# Altium schematic sheet peripheral.SchDoc(peripheral)
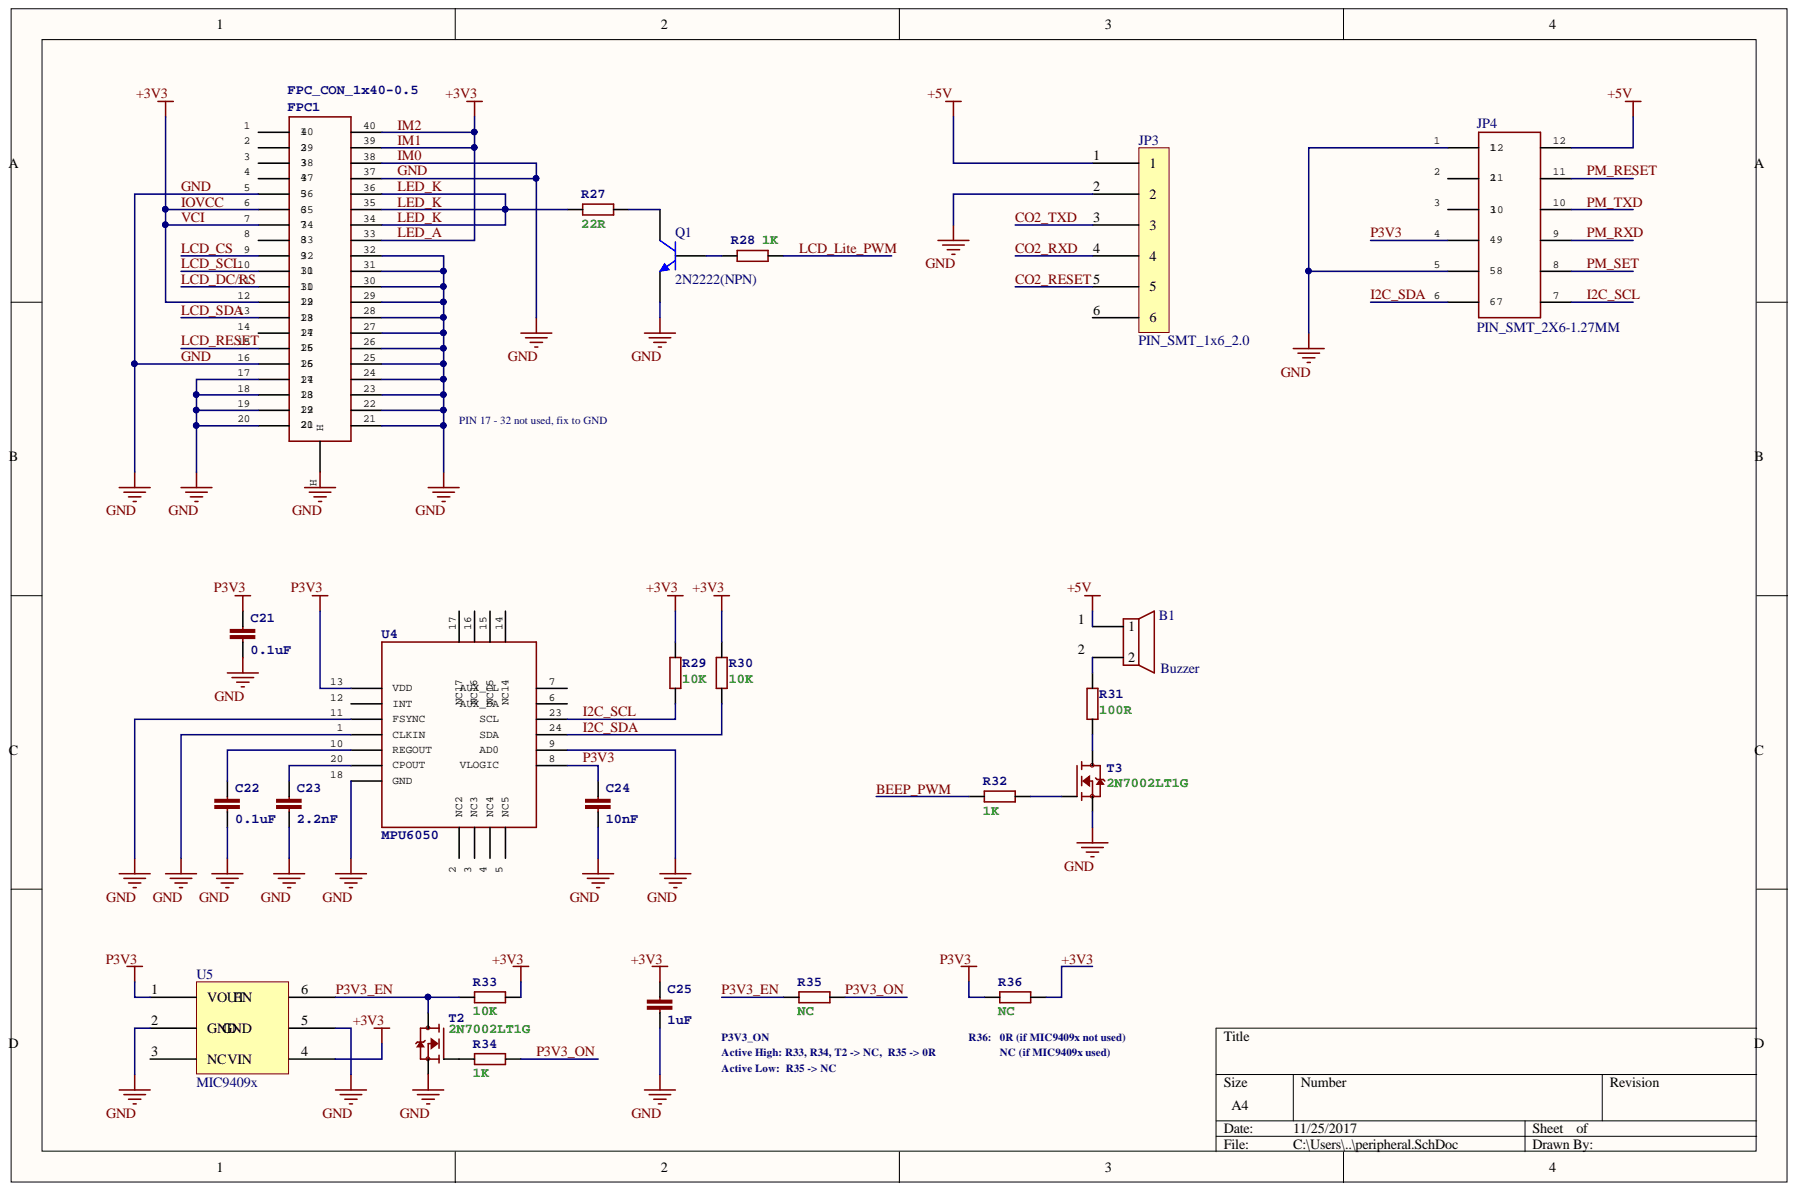
[diagram p0: peripheral.SchDoc]

## Parts
B1  Buzzer  [BUZZER_5020_1]
C21  0.1uF  [C0603]
C22  0.1uF  [C0603]
C23  2.2nF  [C0603]
C24  10nF  [C0603]
C25  1uF  [C0603]
FPC1  FPC_CON_1x40-0.5  [FPC_CON_1X40-0.5MM]
JP3  PIN_SMT_1x6_2.0  [PIN_1x6_SMT_2.0]
JP4  PIN_SMT_2X6-1.27MM  [PIN_2x6_1.27]
Q1  2N2222(NPN)  [S8050(NPN)]
R27  22R  [R0603]
R28  1K  [R0603]
R29  10K  [R0603]
R30  10K  [R0603]
R31  100R  [R0603]
R32  1K  [R0603]
R33  10K  [R0603]
R34  1K  [R0603]
R35  NC  [R0603]
R36  NC  [R0603]
T2  2N7002LT1G  [SOT-23]
T3  2N7002LT1G  [SOT-23]
U4  MPU6050  [QFN-24-4MM2]
U5  MIC9409x  [SC70-6]

## Nets
+5V: B1.1 JP3.1 JP4.12
+3V3: C25.1 FPC1.6 FPC1.7 FPC1.12 FPC1.33 FPC1.39 FPC1.40 R29.2 R30.2 R33.2 R36.1 U5.4
BEEP_PWM: R32.2
CO2_RESET: JP3.5
CO2_RXD: JP3.4
CO2_TXD: JP3.3
GND: C21.2 C22.2 C23.2 C24.2 C25.2 FPC1.5 FPC1.16 FPC1.17 FPC1.18 FPC1.19 FPC1.20 FPC1.21 FPC1.22 FPC1.23 FPC1.24 FPC1.25 FPC1.26 FPC1.27 FPC1.28 FPC1.29 FPC1.30 FPC1.31 FPC1.32 FPC1.37 FPC1.38 FPC1.H JP3.2 JP4.1 JP4.5(5_8) Q1.2 T2.2 T3.2 U4.1(CLKIN) U4.9 U4.11(FSYNC) U4.18(GND) U5.2(GND) U5.5
I2C_SCL: JP4.7 R29.1 U4.23
I2C_SDA: JP4.6(6_7) R30.1 U4.24
LCD_CS: FPC1.9
LCD_DC/RS: FPC1.11
LCD_LITE_PWM: R28.1
LCD_RESET: FPC1.15
LCD_SCL: FPC1.10
LCD_SDA: FPC1.13
LED_K: FPC1.34 FPC1.35 FPC1.36 R27.2
P3V3: C21.1 C24.1 JP4.4(4_9) R36.2 U4.8 U4.13(VDD) U5.1(VOUT)
P3V3_EN: R33.1 R35.2 T2.1 U5.6
P3V3_ON: R34.1 R35.1
PM_RESET: JP4.11
PM_RXD: JP4.9
PM_SET: JP4.8
PM_TXD: JP4.10
NetB1_2: B1.2 R31.2
NetC22_1: C22.1 U4.10(REGOUT)
NetC23_1: C23.1 U4.20(CPOUT)
NetQ1_1: Q1.1 R28.2
NetQ1_3: Q1.3 R27.1
NetR31_1: R31.1 T3.1
NetR32_1: R32.1 T3.3
NetR34_2: R34.2 T2.3

Not connected: FPC1 1 2 3(3_8) 4 8 14; JP3 6; JP4 2 3; U4 2(NC2) 3(NC3) 4(NC4) 5(NC5) 6 7 12(INT) 14 15 16 17; U5 3(NC_VIN)

## Library data of the parts
C21-C25 = C-EUC0603: CAPACITOR, European symbol; Component Kind: Standard; Library Name: shenghua.SCHLIB
FPC1 = FPC_CON_1x40-0.5: FPC_1x40-0.5mm Connector; PART_NUMBER: MCR-B-S-RA-SMT-CS1TR; Component Kind: Standard; Library Name: shenghua.SCHLIB
Q1 = 2N2222: Component Kind: Standard; Library Name: shenghua.SCHLIB
R27-R36 = R-EU_R0603: Component Kind: Standard; Library Name: shenghua.SCHLIB
T2 T3 = 2N7002LT1G: 2N7002 60 V, 300 mA N-channel Trench MOSFET; Component Kind: Standard; Library Name: shenghua.SCHLIB
U4 = MPU-6050: Component Kind: Standard; Library Name: shenghua.SCHLIB
B1 = Buzzer: Component Kind: Standard; Library Name: shenghua.SCHLIB
JP3 = PIN_SMT_1x6_2.0: Component Kind: Standard; Library Name: shenghua.SCHLIB
JP4 = PIN_SMT_2X6-1.27MM: Component Kind: Standard; Library Name: shenghua.SCHLIB
U5 = MIC9409x: Component Kind: Standard; Library Name: shenghua.SCHLIB
